# Altium schematic sheet ASR-2DF.SchDoc
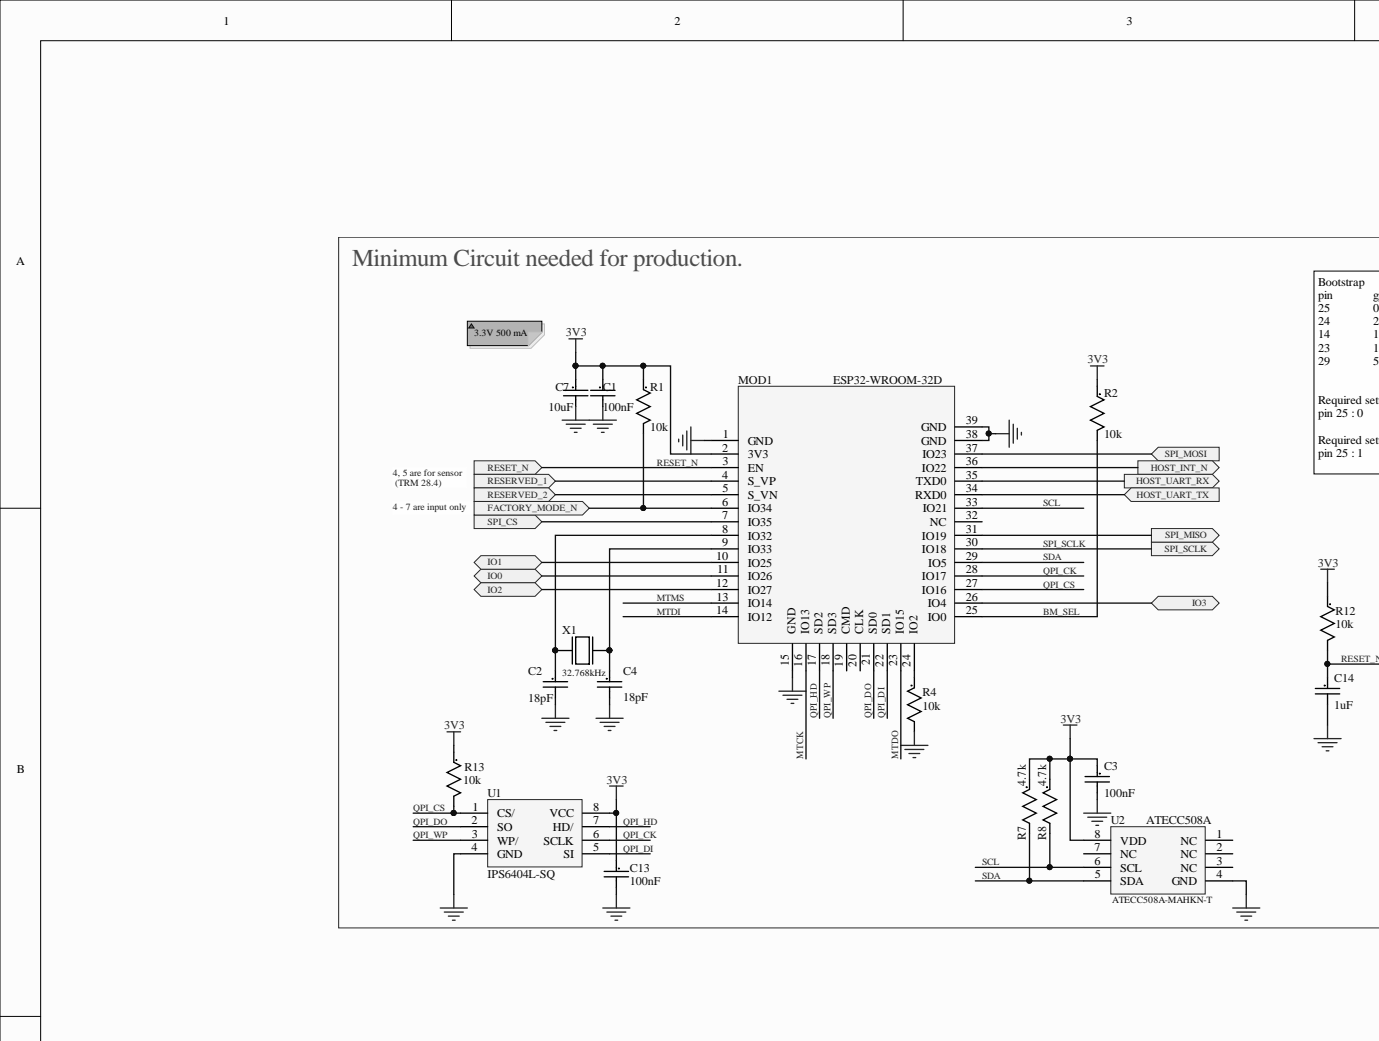
[diagram p0: ASR-2DF.SchDoc, top left]
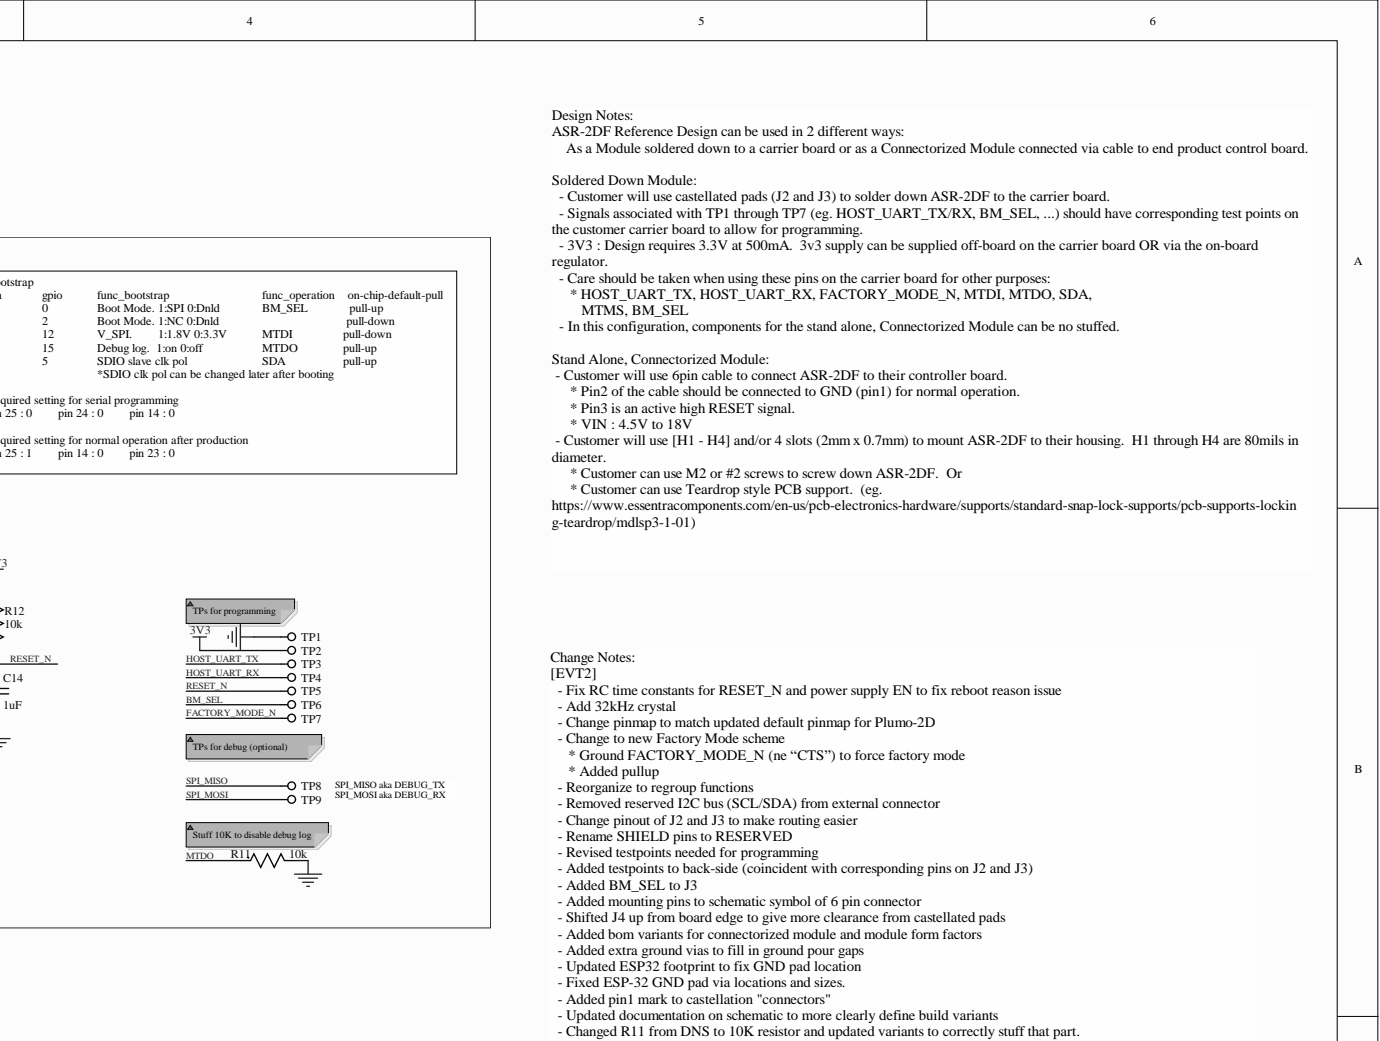
[diagram p1: ASR-2DF.SchDoc, top right]
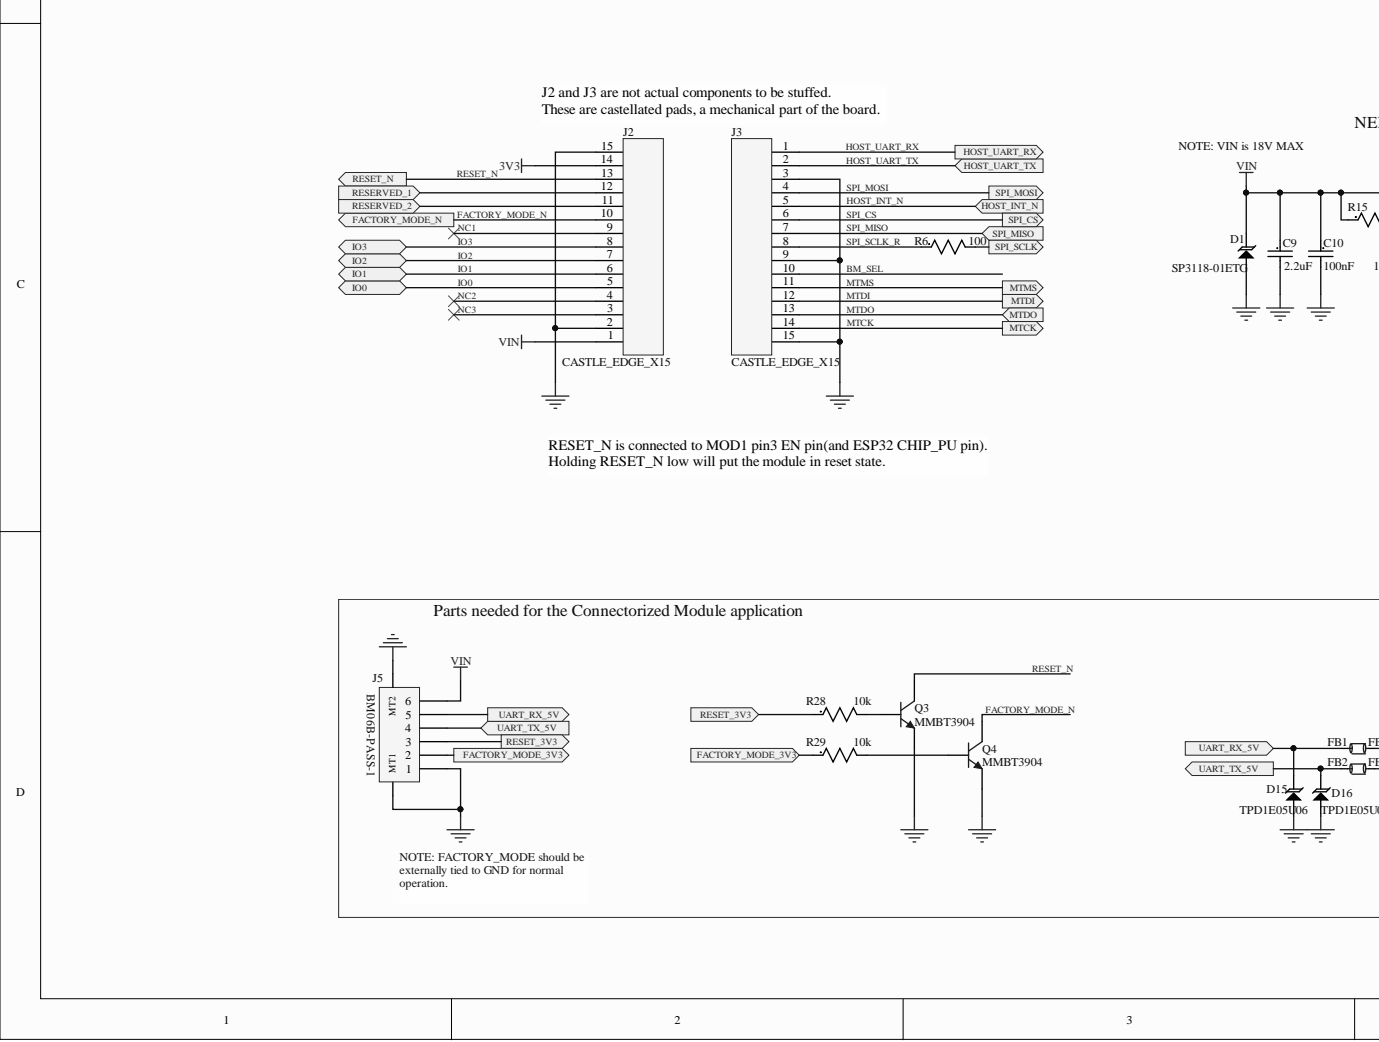
[diagram p2: ASR-2DF.SchDoc, bottom left]
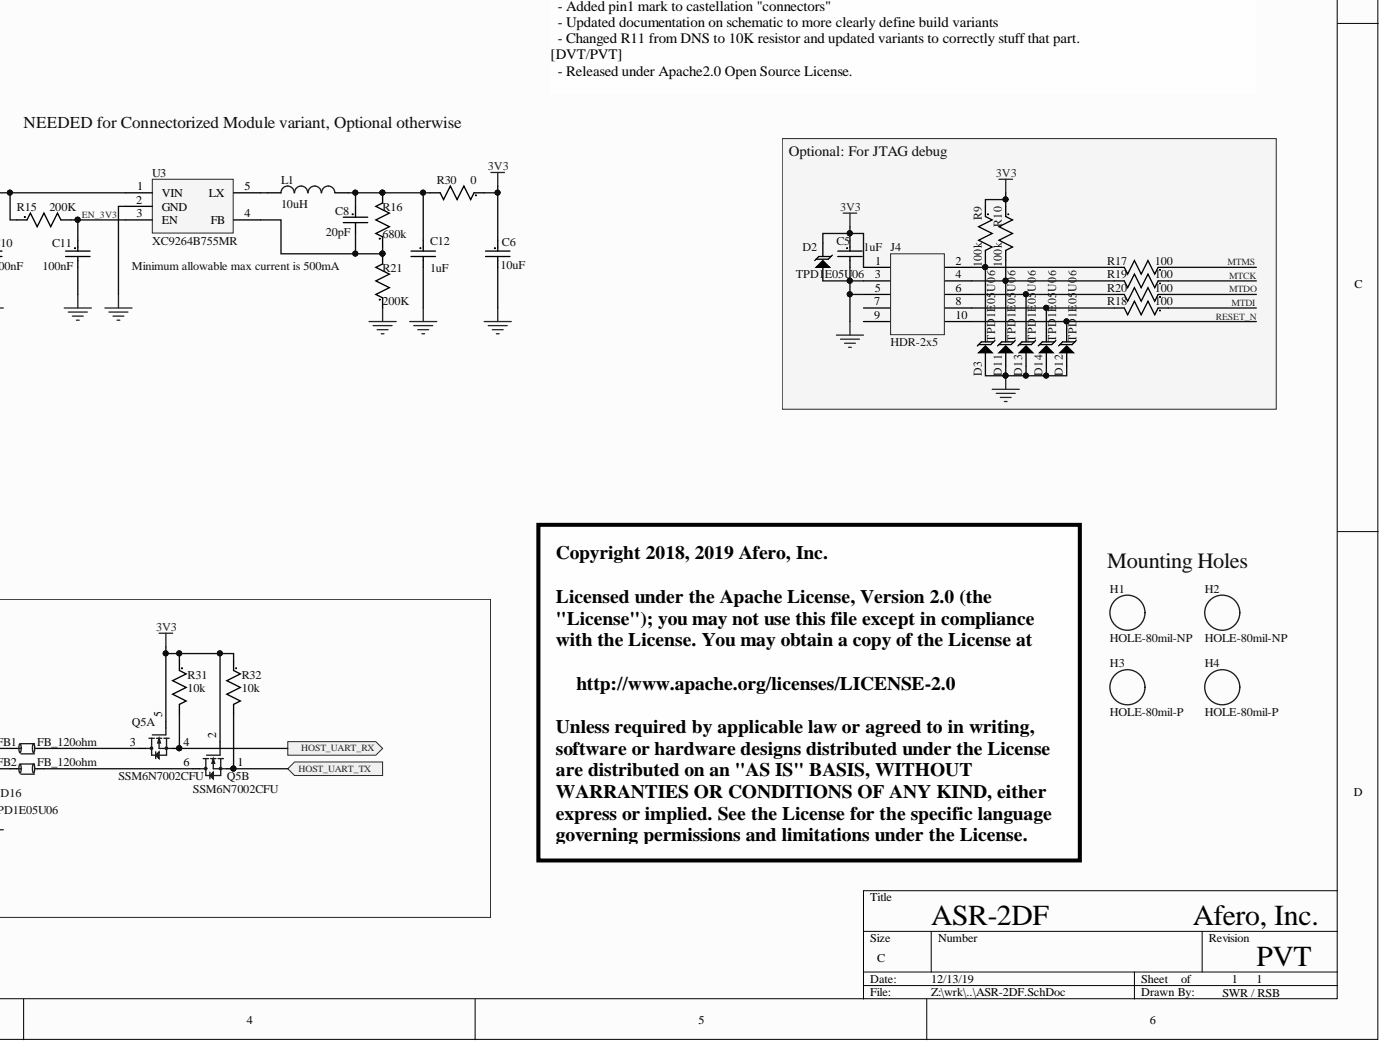
[diagram p3: ASR-2DF.SchDoc, bottom right]

## Parts
C1  100nF  [0402C-GENERIC]
C2  18pF  [0402C-GENERIC]
C3  100nF  [0402C-GENERIC]
C4  18pF  [0402C-GENERIC]
C5  1uF  [0402C-GENERIC]
C6  10uF  [0603C-GENERIC]
C7  10uF  [0603C-GENERIC]
C8  20pF  [0402C-GENERIC]
C9  2.2uF  [0603C-GENERIC]
C10  100nF  [0402C-GENERIC]
C11  100nF  [0402C-GENERIC]
C12  1uF  [0402C-GENERIC]
C13  100nF  [0402C-GENERIC]
C14  1uF  [0402C-GENERIC]
D1  SP3118-01ETG  [SOD882]
D2  TPD1E05U06  [SON70P100X45-2N]
D3  TPD1E05U06  [SON70P100X45-2N]
D11  TPD1E05U06  [SON70P100X45-2N]
D12  TPD1E05U06  [SON70P100X45-2N]
D13  TPD1E05U06  [SON70P100X45-2N]
D14  TPD1E05U06  [SON70P100X45-2N]
D15  TPD1E05U06  [SON70P100X45-2N]
D16  TPD1E05U06  [SON70P100X45-2N]
FB1  FB_120ohm  [BLM15]
FB2  FB_120ohm  [BLM15]
H1  HOLE-80mil-NP  [HOLE-80mil-NP]  (no BOM)
H2  HOLE-80mil-NP  [HOLE-80mil-NP]  (no BOM)
H3  HOLE-80mil-P  [HOLE-80mil-P]  (no BOM)
H4  HOLE-80mil-P  [HOLE-80mil-P]  (no BOM)
J2  CASTLE_EDGE_X15  [CASTL-1X15]  (no BOM)
J3  CASTLE_EDGE_X15  [CASTL-1X15]  (no BOM)
J4  HDR-2x5  [S9015E-05]
J5  BM06B-PASS-1  [BM06B-PASS-1]
L1  10uH  [ASPI-0315FS]
MOD1  ESP32-WROOM-32D  [ESP32-WROOM-32D]
Q3  MMBT3904  [sot23]
Q4  MMBT3904  [sot23]
Q5  SSM6N7002CFU  [SOT363]  (parts A,B)
R1  10k  [0402R-GENERIC]
R2  10k  [0402R-GENERIC]
R4  10k  [0402R-GENERIC]
R6  100  [0402R-GENERIC]
R7  4.7k  [0402R-GENERIC]
R8  4.7k  [0402R-GENERIC]
R9  100k  [0402R-GENERIC]
R10  100k  [0402R-GENERIC]
R11  10k  [0402R-GENERIC]
R12  10k  [0402R-GENERIC]
R13  10k  [0402R-GENERIC]
R15  200K  [0402R-GENERIC]
R16  680k  [0402R-GENERIC]
R17  100  [0402R-GENERIC]
R18  100  [0402R-GENERIC]
R19  100  [0402R-GENERIC]
R20  100  [0402R-GENERIC]
R21  200K  [0402R-GENERIC]
R28  10k  [0402R-GENERIC]
R29  10k  [0402R-GENERIC]
R30  0  [0402R-GENERIC]
R31  10k  [0402R-GENERIC]
R32  10k  [0402R-GENERIC]
TP1  TP1MM  [TP1MM]  (value not drawn)  (no BOM)
TP2  TP1MM  [TP1MM]  (value not drawn)  (no BOM)
TP3  TP1MM  [TP1MM]  (value not drawn)  (no BOM)
TP4  TP1MM  [TP1MM]  (value not drawn)  (no BOM)
TP5  TP1MM  [TP1MM]  (value not drawn)  (no BOM)
TP6  TP1MM  [TP1MM]  (value not drawn)  (no BOM)
TP7  TP1MM  [TP1MM]  (value not drawn)  (no BOM)
TP8  TP1MM  [TP1MM]  (value not drawn)  (no BOM)
TP9  TP1MM  [TP1MM]  (value not drawn)  (no BOM)
U1  IPS6404L-SQ  [IPUS.PSRAM]
U2  ATECC508A  Manufacturer Part Number: ATECC508A-MAHKN-T  [SON50P300X60-8N]
U3  XC9264B755MR  [SOT95P275X130-5N]
X1  32.768kHz  [ABS06]

## Ports
FACTORY_MODE_3V3, FACTORY_MODE_N, HOST_INT_N, HOST_UART_RX, HOST_UART_TX, IO0, IO1, IO2, IO3, MTCK, MTDI, MTDO, MTMS, RESERVED_1, RESERVED_2, RESET_3V3, RESET_N, SPI_CS, SPI_MISO, SPI_MOSI, SPI_SCLK, UART_RX_5V, UART_TX_5V

## Nets
3V3: C1.1 C3.1 C5.1 C6.1 C7.1 C13.1 D2.1 J2.14 J4.1 MOD1.2(3V3) Q5.2 Q5.5 R1.1 R2.1 R7.1 R8.1 R9.1 R10.1 R12.1 R13.1 R30.1 R31.1 R32.1 TP2.1 U1.8(VCC) U2.8(VDD)
GND: C1.2 C2.2 C3.2 C4.2 C5.2 C6.2 C7.2 C9.2 C10.2 C11.2 C12.2 C13.2 C14.2 D1.2 D2.2 D3.2 D11.2 D12.2 D13.2 D14.2 D15.2 D16.2 J2.2 J2.15 J3.3 J3.9 J3.15 J4.3 J4.5 J5.1 J5.7(MT1) J5.8(MT2) MOD1.1(GND) MOD1.15(GND) MOD1.38(GND) MOD1.39(GND) Q3.2 Q4.2 R4.2 R11.2 R21.1 TP1.1 U1.4(GND) U2.4(GND) U3.2(GND)
VIN: C9.1 C10.1 D1.1 J2.1 J5.6 R15.1 U3.1(VIN)
BM_SEL: J3.10 MOD1.25(IO0) R2.2 TP6.1
EN_3V3: C11.1 R15.2 U3.3(EN)
FACTORY_MODE_3V3: J5.2 R29.1 ; port FACTORY_MODE_3V3
FACTORY_MODE_N: J2.10 MOD1.6(IO34) Q4.3 R1.2 TP7.1 ; port FACTORY_MODE_N
HOST_INT_N: J3.5 MOD1.36(IO22) ; port HOST_INT_N
HOST_UART_RX: J3.1 MOD1.35(TXD0) Q5.4 R31.2 TP4.1 ; port HOST_UART_RX
HOST_UART_TX: J3.2 MOD1.34(RXD0) Q5.1 R32.2 TP3.1 ; port HOST_UART_TX
IO0: J2.5 MOD1.11(IO26) ; port IO0
IO1: J2.6 MOD1.10(IO25) ; port IO1
IO2: J2.7 MOD1.12(IO27) ; port IO2
IO3: J2.8 MOD1.26(IO4) ; port IO3
MTCK: J3.14 MOD1.16(IO13) R19.1 ; port MTCK
MTDI: J3.12 MOD1.14(IO12) R18.1 ; port MTDI
MTDO: J3.13 MOD1.23(IO15) R11.1 R20.1 ; port MTDO
MTMS: J3.11 MOD1.13(IO14) R17.1 ; port MTMS
NC1: J2.9
NC2: J2.4
NC3: J2.3
QPI_CK: MOD1.28(IO17) U1.6(SCLK)
QPI_CS: MOD1.27(IO16) R13.2 U1.1(CS/)
QPI_DI: MOD1.22(SD1) U1.5(SI)
QPI_DO: MOD1.21(SD0) U1.2(SO)
QPI_HD: MOD1.17(SD2) U1.7(HD/)
QPI_WP: MOD1.18(SD3) U1.3(WP/)
RESERVED_1: J2.12 MOD1.4(S_VP) ; port RESERVED_1
RESERVED_2: J2.11 MOD1.5(S_VN) ; port RESERVED_2
RESET_3V3: J5.3 R28.1 ; port RESET_3V3
RESET_N: C14.1 D12.1 J2.13 J4.10 MOD1.3(EN) Q3.3 R12.2 TP5.1 ; port RESET_N
SCL: MOD1.33(IO21) R8.2 U2.6(SCL)
SDA: MOD1.29(IO5) R7.2 U2.5(SDA)
SPI_CS: J3.6 MOD1.7(IO35) ; port SPI_CS
SPI_MISO: J3.7 MOD1.31(IO19) TP8.1 ; port SPI_MISO
SPI_MOSI: J3.4 MOD1.37(IO23) TP9.1 ; port SPI_MOSI
SPI_SCLK: MOD1.30(IO18) R6.2 ; port SPI_SCLK
SPI_SCLK_R: J3.8 R6.1
UART_RX_5V: D15.1 FB1.1 J5.5 ; port UART_RX_5V
UART_TX_5V: D16.1 FB2.1 J5.4 ; port UART_TX_5V
NetC2_1: C2.1 MOD1.8(IO32) X1.2
NetC4_1: C4.1 MOD1.9(IO33) X1.1
NetC8_1: C8.1 C12.1 L1.2 R16.2 R30.2
NetC8_2: C8.2 R16.1 R21.2 U3.4(FB)
NetD3_1: D3.1 J4.2 R9.2 R17.2
NetD11_1: D11.1 J4.4 R10.2 R19.2
NetD13_1: D13.1 J4.6 R20.2
NetD14_1: D14.1 J4.8 R18.2
NetFB1_2: FB1.2 Q5.3
NetFB2_2: FB2.2 Q5.6
NetL1_1: L1.1 U3.5(LX)
NetMOD1_24: MOD1.24(IO2) R4.1
NetQ3_1: Q3.1 R28.2
NetQ4_1: Q4.1 R29.2

Not connected: J4 7 9; MOD1 19(CMD) 20(CLK) 32(NC); U2 1(NC) 2(NC) 3(NC) 7(NC)

## Library data of the parts
C1 C3 C10 C11 C13 = 0402C-100n: Capacitor 100nF X5R 50V 10% 0402; Manufacturer: Murata; Manufacturer Part Number: GRM155R61H104KE19D; item_number: E-CAP-00090-00; Capacitance: 100nF; Case/Package: 0402; Case Code (Imperial): 0402; Case Code (Metric): 1005; Component Kind: Standard; Depth: 0.5mm; Dielectric: X5R; Height: 0.5mm; Lead Free: Lead Free; Length: 1mm; Max Operating Temperature: 85°C; Min Operating Temperature: -55°C; Mount: Surface Mount; Packaging: Tape and Reel; Pricing 1: 1=0.1, 10=0.068, 50=0.068, 100=0.03, 1000=0.017, 10000=0.011 (USD); REACH SVHC: No SVHC; Stock 1: 727844; Supplier 1: Mouser; Supplier Part Number 1: 81-GRM155R61H104KE9D; Thickness: 0.022inch; Tolerance: 10%; Voltage: 50V; Voltage Rating: 50V; Voltage Rating (DC): 50V; Width: 0.02inch; Library Name: ASR-2DF.IntLib
C2 C4 = 0402C-18p: Capacitor, 18pF, 50V, 1%, C0G; Manufacturer: Murata; Manufacturer Part Number: GJM1555C1H180FB01D; item_number: E-CAP-00110-00; Capacitance: 18pF; Case/Package: 0402; Case Code (Imperial): 0402; Case Code (Metric): 1005; Component Kind: Standard; Depth: 0.5mm; Dielectric: C0G; Height: 0.5mm; Lead Free: Lead Free; Length: 1mm; Max Operating Temperature: 125°C; Min Operating Temperature: -55°C; Mount: Surface Mount; Package Quantity: 10000; Packaging: Tape and Reel; Radiation Hardening: No; REACH SVHC: No SVHC; Termination: SMD/SMT; Thickness: 0.022inch; Tolerance: 1%; Voltage Rating: 50V; Voltage Rating (DC): 50V; Width: 0.02inch; Library Name: ASR-2DF.IntLib
C5 C12 C14 = 0402C-1u: Capacitor 1uF X5R 6.3V 20% 0402; Manufacturer Part Number: CL05A105KQ5NNNC; Brand: SEM; Capacitance - nF: 1000 nF; item_number: E-CAP-00020-00; Type: Chip Monolithic Ceramic Capacitor; Value: 1u; Voltage Rating DC: 6.3 VDC; Capacitance: 1uF; Case Code - in: 0402; Case Code - mm: 1005; Category: Multilayer Ceramic Capacitors MLCC - SMD/SMT; Component Kind: Standard; Dielectric: X5R; Height: 0.5 mm; Length: 1 mm; Manufacturer: SEM; Maximum Operating Temperature: + 85 C; Minimum Operating Temperature: - 55 C; Minimum Order Quantity: 1; Package / Case: 0402 (1005 metric); Packaging: Cut Tape; Product: General Type MLCCs; Reeling: True; RoHS: RoHS Compliant; RoHS - Mouser: Y; Series: GRM; Standard Pack Qty: 50000; Termination Style: SMD/SMT; Tolerance: 20 %; Width: 0.5 mm; Library Name: ASR-2DF.IntLib
C6 C7 = 0603C-10u: Capacitor 10uF X5R 16V 20% 0603; Manufacturer: Murata; Manufacturer Part Number: GRM188R61C106MA73D; item_number: E-CAP-00100-00; Material: Ceramic; Value: 10u; Capacitance: 10uF; Case/Package: 0603; Case Code (Imperial): 0603; Case Code (Metric): 1608; Component Kind: Standard; Depth: 0.8mm; Dielectric: X5R; Height: 1mm; Lead Free: Lead Free; Length: 1.6mm; Max Operating Temperature: 85°C; Min Operating Temperature: -55°C; Mount: Surface Mount; Package Quantity: 4000; Packaging: Tape and Reel; REACH SVHC: No SVHC; Termination: SMD/SMT; Thickness: 0.037inch; Tolerance: 20%; Voltage Rating: 16V; Voltage Rating (DC): 16V; Weight: 0.000222oz; Width: 0.8mm; Library Name: ASR-2DF.IntLib
C8 = 0402C-20p: Capacitor 20pF C0G 50V 1% 0402; Manufacturer: Murata; Manufacturer Part Number: GJM1555C1H200FB01D; item_number: E-CAP-00107-00; Capacitance: 20pF; Case/Package: 0402; Case Code (Imperial): 0402; Case Code (Metric): 1005; Component Kind: Standard; Depth: 0.5mm; Dielectric: C0G; Height: 0.5mm; Lead Free: Lead Free; Length: 1mm; Max Operating Temperature: 125°C; Min Operating Temperature: -55°C; Mount: Surface Mount; Package Quantity: 10000; Packaging: Tape and Reel; Radiation Hardening: No; REACH SVHC: No SVHC; Termination: SMD/SMT; Thickness: 0.022inch; Tolerance: 1%; Voltage Rating: 50V; Voltage Rating (DC): 50V; Width: 0.02inch; Library Name: ASR-2DF.IntLib
C9 = 0603C-2u2: Capacitor 2.2uF X5R 25V 10% 0603; Manufacturer: Murata; Manufacturer Part Number: GRM188R61E225KA12D; item_number: E-CAP-00108-00; Material: Ceramic; Value: 2u2; Capacitance: 2.2uF; Case/Package: 0603; Case Code (Imperial): 0603; Case Code (Metric): 1608; Component Kind: Standard; Depth: 0.8mm; Dielectric: X5R; Height: 0.9mm; Lead Free: Lead Free; Length: 1.6mm; Max Operating Temperature: 85°C; Min Operating Temperature: -55°C; Mount: Surface Mount; Package Quantity: 4000; Packaging: Tape and Reel; REACH SVHC: No SVHC; Termination: SMD/SMT; Thickness: 0.037inch; Tolerance: 10%; Voltage Rating: 25V; Voltage Rating (DC): 25V; Weight: 0.000222oz; Width: 0.8mm; Library Name: ASR-2DF.IntLib
D1 = SP3118-01ETG: Diode TVS; Manufacturer: Littelfuse; Capacitance: 0.3pF; Clamping Voltage: 38V; Direction: Bidirectional; Element Configuration: Single; item_number: E-DIO-00011-00; Leakage Current: 50nA; Max Power Dissipation: 76W; Number of Bidirectional Channels: 1; Operating Supply Voltage: 18V; Peak Pulse Current: 2A; Power Line Protection: Yes; Component Kind: Standard; Lead Free: Lead Free; Length: 1mm; Manufacturer Part Number: SP3118-01ETG; Max Operating Temperature: 125°C; Min Breakdown Voltage: -; Min Operating Temperature: -40°C; Mount: Surface Mount; Number of Channels: 1; Number of Pins: 2; Package Quantity: 10000; Packaging: Tape and Reel; Peak Pulse Power: 76W; Polarity: Bidirectional; Radiation Hardening: No; REACH SVHC: No SVHC; Reverse Standoff Voltage: 18V; Termination: SMD/SMT; Working Voltage: 18V; Library Name: ASR-2DF.IntLib
D2 D3 D11-D16 = TPD1E05U06: Diode TVS; Manufacturer: Texas Instruments; Manufacturer Part Number: TPD1E05U06DPYR; Breakdown Voltage: 6.5V; Capacitance: 0.5pF; Clamping Voltage: 14V; Direction: Unidirectional; Element Configuration: Single; Interface: LVDS,USB,Ethernet,HDMI,PCIe,SATA; item_number: E-DIO-00008-00; Leakage Current: 10nA; Max Power Dissipation: 40W; Min Breakdown Voltage: 6V; Number of Bidirectional Channels: 1; Operating Supply Voltage: 5.5V; Peak Pulse Current: 2.5A; Polarity: Bidirectional; Power Line Protection: Yes; Component Kind: Standard; ComponentLink1Description: https://datasheet.ciiva.com/12545/tpd1e05u06-224685-12545140.pdf; ComponentLink1URL: https://datasheet.ciiva.com/12545/tpd1e05u06-224685-12545140.pdf; ComponentLink2Description: https://datasheet.ciiva.com/26918/getdatasheetpartid-700473-26918022.pdf; ComponentLink2URL: https://datasheet.ciiva.com/26918/getdatasheetpartid-700473-26918022.pdf; ComponentLink3Description: https://datasheet.ciiva.com/6574/tpd1e05u06-6574667.pdf; ComponentLink3URL: https://datasheet.ciiva.com/6574/tpd1e05u06-6574667.pdf; Lead Free: Lead Free; Length: 1.1mm; Max Operating Temperature: 125°C; Min Operating Temperature: -40°C; Mount: Surface Mount; Number of Channels: 1; Number of Pins: 2; Package Quantity: 10000; Packaging: Tape and Reel; Peak Pulse Power: 40W; Pricing 1: 1=0.5, 10=0.372, 100=0.2107, 500=0.1395, 1000=0.107 (USD); Radiation Hardening: No; REACH SVHC: No SVHC; Reverse Standoff Voltage: 5.5V; Stock 1: 161045; Supplier 1: Digi-Key; Supplier Part Number 1: 296-39341-1-ND; Termination: SMD/SMT; Working Voltage: 5.5V; Library Name: ASR-2DF.IntLib
FB1 FB2 = FB_120ohm: FERRITE BEAD 120OHM 0402 1LN; Manufacturer: Murata; Manufacturer Part Number: BLM15AG121SN1D; CLASS: DISCRETE; item_number: E-IND-00038-00; Component Kind: Standard; Library Name: ASR-2DF.IntLib
H1 H2 = HOLE-80mil-NP: 0.08" Unplated Hole with 0.1" keepout, #2 screw; Library Name: ASR-2DF.IntLib
H3 H4 = HOLE-80mil-P: 0.08" Plated Hole, #2 screw; Library Name: ASR-2DF.IntLib
J2 J3 = CASTLE_EDGE_X15: 0.07" castellated board edge connector 15 positions; Library Name: ASR-2DF.IntLib
J4 = HDR-2x5: .050 Male Header 2x5; Manufacturer Part Number: GRPB052VWVN-RC; item_number: M-CON-00016-00; Component Kind: Standard; Library Name: ASR-2DF.IntLib
J5 = BM06B-PASS-1: JST PA-Family Vertical Header 2mm; Manufacturer Part Number: BM06B-PASS-1-TFT; item_number: M-CON-00088; Component Kind: Standard; Manufacturer: JST; Library Name: ASR-2DF.IntLib
L1 = ASPI-0315FS-100M: Inductor 10uH 1.1A 0.22ohm 20% 3x3; Manufacturer: Abracon; item_number: E-IND-00043-00; Component Kind: Standard; Manufacturer Part Number: ASPI-0315FS-100M; Value: 10uH; Library Name: ASR-2DF.IntLib
MOD1 = ESP32-WROOM-32D: Module WIFI BLE ESP32-D0WD SPI Flash; Manufacturer: Espressif; Item_number: E-ICS-00097-00; Component Kind: Standard; Manufacturer Part Number: ESP32-WROOM-32D; Size: 25.5mm x 18mm; Library Name: ASR-2DF.IntLib
Q3 Q4 = MMBT3904: TR NPN BJT 200mA 40V; Manufacturer: On Semiconductor; Manufacturer Part Number: MMBT3904LT1G; item_number: E-TRS-00002-00; Component Kind: Standard; ComponentLink1Description: Manufacturer Link; ComponentLink1URL: http://www.fairchildsemi.com/; ComponentLink2Description: Datasheet; ComponentLink2URL: http://www.fairchildsemi.com/ds/2N%2F2N3904.pdf; Package/Case: SOT23; Library Name: ASR-2DF.IntLib
Q5 = SSM6N7002CFU: TR 2xNFET 170mA 60V; Manufacturer: Toshiba; Manufacturer Part Number: SSM6N7002CFU.LF; item_number: E-TRS-00009-00; Case/Package: SOT-363; Component Kind: Standard; Library Name: ASR-2DF.IntLib
R1 R2 R4 R11-R13 R28 R29 R31 R32 = 0402R-10k: Resistor 10K 50V 5% 0402; Manufacturer: Vishay Dale; Manufacturer Part Number: CRCW040210K0JNED; item_number: E-RES-00001-00; Max Power Dissipation: 63mW; Temperature Coefficient: 200ppm/°C; Case/Package: 0402; Case Code (Imperial): 0402; Case Code (Metric): 1005; Component Kind: Standard; ComponentLink1Description: https://datasheet.ciiva.com/2461/dcrcwe3-2461974.pdf; ComponentLink1URL: https://datasheet.ciiva.com/2461/dcrcwe3-2461974.pdf; ComponentLink2Description: https://datasheet.ciiva.com/2461/dcrcwe3-2461975.pdf; ComponentLink2URL: https://datasheet.ciiva.com/2461/dcrcwe3-2461975.pdf; ComponentLink3Description: https://datasheet.ciiva.com/2461/dcrcwe3-2461976.pdf; ComponentLink3URL: https://datasheet.ciiva.com/2461/dcrcwe3-2461976.pdf; ComponentLink4Description: https://datasheet.ciiva.com/7743/dcrcwe3-7743416.pdf; ComponentLink4URL: https://datasheet.ciiva.com/7743/dcrcwe3-7743416.pdf; ComponentLink5Description: https://datasheet.ciiva.com/16771/70201119-16771096.pdf; ComponentLink5URL: https://datasheet.ciiva.com/16771/70201119-16771096.pdf; ComponentLink6Description: https://datasheet.ciiva.com/7743/dcrcwe3-7743417.pdf; ComponentLink6URL: https://datasheet.ciiva.com/7743/dcrcwe3-7743417.pdf; ComponentLink7Description: https://datasheet.ciiva.com/16761/70201119-16761947.pdf; ComponentLink7URL: https://datasheet.ciiva.com/16761/70201119-16761947.pdf; ComponentLink8Description: https://datasheet.ciiva.com/3255/dcrcwe3-3255964.pdf; ComponentLink8URL: https://datasheet.ciiva.com/3255/dcrcwe3-3255964.pdf; ComponentLink9Description: https://datasheet.ciiva.com/3255/dcrcwe3-3255965.pdf; ComponentLink9URL: https://datasheet.ciiva.com/3255/dcrcwe3-3255965.pdf; Composition: Thick Film; Contact Plating: Tin; Depth: 0.5mm; Features: Automotive AEC-Q200; Height: 0.016inch; Length: 1mm; Max Operating Temperature: 155°C; Military Standard: Not; Min Operating Temperature: -55°C; Mount: Surface Mount; Number of Terminations: 2; Package Quantity: 1; Packaging: Tape and Reel; Power Rating: 63mW; Pricing 1: 1=0.1, 10=0.031, 100=0.0125, 1000=0.0056, 2500=0.0049, 5000=0.004 (USD); Radiation Hardening: No; REACH SVHC: Unknown; Resistance: 10k; Stock 1: 0; Supplier 1: Digi-Key; Supplier Part Number 1: 541-10KJCT-ND; Termination: SMD/SMT; Tolerance: 5%; Voltage Rating: 50V; Weight: 2.3E-05oz; Width: 0.5mm; Library Name: ASR-2DF.IntLib
R6 R17-R20 = 0402R-100R: Resistor 100R 50V 5% 0402; Manufacturer: Panasonic; Manufacturer Part Number: ERJ-2GEJ101X; item_number: E-RES-00008-00; Max Power Dissipation: 100mW; Temperature Coefficient: 100ppm/°C; Case/Package: 0402; Case Code (Imperial): 0402; Case Code (Metric): 1005; Component Kind: Standard; Composition: Thick Film; Contact Plating: Tin; Depth: 0.5mm; Features: Automotive AEC-Q200; Height: 0.35mm; Lead Free: Lead Free; Length: 1mm; Max Operating Temperature: 155°C; Military Standard: Not; Min Operating Temperature: -55°C; Mount: Surface Mount; Number of Terminations: 2; Package Quantity: 10; Packaging: Tape and Reel; Power Rating: 100mW; Pricing 1: 1=0.1, 10=0.044, 100=0.0178, 1000=0.008, 2500=0.007, 5000=0.0058 (USD); Radiation Hardening: No; REACH SVHC: Unknown; Resistance: 100; Termination: SMD/SMT; Tolerance: 5%; Voltage Rating: 50V; Voltage Rating (DC): 50V; Weight: 2.3E-05oz; Width: 0.02inch; Working Voltage: 50V; Library Name: ASR-2DF.IntLib
R7 R8 = 0402R-4k7: Resistor 4.7K 50V 5% 0402; Manufacturer: Panasonic; Manufacturer Part Number: ERJ-2GEJ472X; item_number: E-RES-00039-00; Max Power Dissipation: 100mW; Temperature Coefficient: 200ppm/°C; Case/Package: 0402; Case Code (Imperial): 0402; Case Code (Metric): 1005; Component Kind: Standard; ComponentLink1Description: https://datasheet.ciiva.com/11821/0900766b80f806dd-11821328.pdf; ComponentLink1URL: https://datasheet.ciiva.com/11821/0900766b80f806dd-11821328.pdf; ComponentLink2Description: https://datasheet.ciiva.com/16758/70260739-16758341.pdf; ComponentLink2URL: https://datasheet.ciiva.com/16758/70260739-16758341.pdf; ComponentLink3Description: https://datasheet.ciiva.com/16770/70260739-16770309.pdf; ComponentLink3URL: https://datasheet.ciiva.com/16770/70260739-16770309.pdf; ComponentLink4Description: https://datasheet.ciiva.com/28514/1678796-28514168.pdf; ComponentLink4URL: https://datasheet.ciiva.com/28514/1678796-28514168.pdf; ComponentLink5Description: https://datasheet.ciiva.com/17213/aoa0000ce1-17213178.pdf; ComponentLink5URL: https://datasheet.ciiva.com/17213/aoa0000ce1-17213178.pdf; ComponentLink6Description: https://datasheet.ciiva.com/16523/aoa0000ce1-16523499.pdf; ComponentLink6URL: https://datasheet.ciiva.com/16523/aoa0000ce1-16523499.pdf; ComponentLink7Description: https://datasheet.ciiva.com/46377/1678796-46377565.pdf; ComponentLink7URL: https://datasheet.ciiva.com/46377/1678796-46377565.pdf; Composition: Thick Film; Contact Plating: Tin; Depth: 0.5mm; Features: Automotive AEC-Q200; Height: 0.35mm; Lead Free: Lead Free; Length: 1mm; Max Operating Temperature: 155°C; Military Standard: Not; Min Operating Temperature: -55°C; Mount: Surface Mount; Number of Terminations: 2; Package Quantity: 1; Packaging: Cut Tape; Power Rating: 100mW; Pricing 1: 1=0.1, 10=0.038, 100=0.0154, 1000=0.0069, 2500=0.006, 5000=0.005 (USD); Radiation Hardening: No; REACH SVHC: No SVHC; Resistance: 4.7k; Stock 1: 10404054; Supplier 1: Digi-Key; Supplier Part Number 1: P4.7KJCT-ND; Termination: SMD/SMT; Tolerance: 5%; Voltage Rating: 50V; Voltage Rating (DC): 50V; Width: 0.5mm; Library Name: ASR-2DF.IntLib
R9 R10 = 0402R-100k: Resistor 100K 50V 5% 0402; Manufacturer: Panasonic; Manufacturer Part Number: ERJ-2GEJ104X; item_number: E-RES-00012-00; Max Power Dissipation: 100mW; Temperature Coefficient: 200ppm/°C; Case/Package: 0402; Case Code (Imperial): 0402; Case Code (Metric): 1005; Component Kind: Standard; ComponentLink1Description: https://datasheet.ciiva.com/11821/0900766b80f806dd-11821174.pdf; ComponentLink1URL: https://datasheet.ciiva.com/11821/0900766b80f806dd-11821174.pdf; ComponentLink2Description: https://datasheet.ciiva.com/22758/1500035-22758198.pdf; ComponentLink2URL: https://datasheet.ciiva.com/22758/1500035-22758198.pdf; ComponentLink3Description: https://datasheet.ciiva.com/34303/1986799-34303543.pdf; ComponentLink3URL: https://datasheet.ciiva.com/34303/1986799-34303543.pdf; ComponentLink4Description: https://datasheet.ciiva.com/24023/aoa0000ce1-37422-24023480.pdf; ComponentLink4URL: https://datasheet.ciiva.com/24023/aoa0000ce1-37422-24023480.pdf; ComponentLink5Description: https://datasheet.ciiva.com/16777/70260739-16777321.pdf; ComponentLink5URL: https://datasheet.ciiva.com/16777/70260739-16777321.pdf; ComponentLink6Description: https://datasheet.ciiva.com/16759/70260739-16759692.pdf; ComponentLink6URL: https://datasheet.ciiva.com/16759/70260739-16759692.pdf; ComponentLink7Description: https://datasheet.ciiva.com/48531/aoa0000ce1-48531084.pdf; ComponentLink7URL: https://datasheet.ciiva.com/48531/aoa0000ce1-48531084.pdf; ComponentLink8Description: https://datasheet.ciiva.com/9186/aoa0000ce1-9186685.pdf; ComponentLink8URL: https://datasheet.ciiva.com/9186/aoa0000ce1-9186685.pdf; ComponentLink9Description: https://datasheet.ciiva.com/30204/4aoa0000ce1-30204557.pdf; ComponentLink9URL: https://datasheet.ciiva.com/30204/4aoa0000ce1-30204557.pdf; ComponentLink10Description: https://datasheet.ciiva.com/46389/1500035-46389645.pdf; ComponentLink10URL: https://datasheet.ciiva.com/46389/1500035-46389645.pdf; Composition: Thick Film; Contact Plating: Tin; Depth: 0.5mm; Features: Automotive AEC-Q200; Height: 0.35mm; Lead Free: Lead Free; Length: 1mm; Max Operating Temperature: 155°C; Military Standard: Not; Min Operating Temperature: -55°C; Mount: Surface Mount; Number of Terminations: 2; Package Quantity: 1; Packaging: Tape and Reel; Power Rating: 100mW; Pricing 1: 1=0.1, 10=0.038, 100=0.0154, 1000=0.0069, 2500=0.006, 5000=0.005 (USD); Radiation Hardening: No; REACH SVHC: No SVHC; Resistance: 100k; Stock 1: 10583442; Supplier 1: Digi-Key; Supplier Part Number 1: P100KJCT-ND; Termination: SMD/SMT; Tolerance: 5%; Voltage Rating: 50V; Voltage Rating (DC): 50V; Width: 0.02inch; Library Name: ASR-2DF.IntLib
R15 R21 = 0402R-200k: Resistor 200K 50V 1% 0402; Manufacturer: Panasonic; Manufacturer Part Number: ERJ-2RKF2003X; item_number: E-RES-00054-00; Max Power Dissipation: 100mW; Temperature Coefficient: 200ppm/°C; Case/Package: 0402; Case Code (Imperial): 0402; Case Code (Metric): 1005; Component Kind: Standard; Composition: Thick Film; Contact Plating: Tin; Depth: 0.5mm; Features: Automotive AEC-Q200; Height: 0.35mm; Lead Free: Lead Free; Length: 1mm; Max Operating Temperature: 155°C; Military Standard: Not; Min Operating Temperature: -55°C; Mount: Surface Mount; Number of Terminations: 2; Package Quantity: 1; Packaging: Tape and Reel; Power Rating: 100mW; Radiation Hardening: No; REACH SVHC: No SVHC; Resistance: 200K; Termination: SMD/SMT; Tolerance: 1%; Voltage Rating: 50V; Voltage Rating (DC): 50V; Width: 0.02inch; Library Name: ASR-2DF.IntLib
R16 = 0402R-680k: Resistor 680K 50V 5% 0402; Manufacturer: Panasonic; Manufacturer Part Number: ERJ-2GEJ684X; item_number: E-RES-00093-00; Max Power Dissipation: 100mW; Temperature Coefficient: 200ppm/°C; Case/Package: 0402; Case Code (Imperial): 0402; Case Code (Metric): 1005; Component Kind: Standard; Composition: Thick Film; Contact Plating: Tin; Depth: 0.5mm; Features: Automotive AEC-Q200; Height: 0.35mm; Lead Free: Lead Free; Length: 1mm; Max Operating Temperature: 155°C; Military Standard: Not; Min Operating Temperature: -55°C; Mount: Surface Mount; Number of Terminations: 2; Package Quantity: 1; Packaging: Tape and Reel; Power Rating: 100mW; Radiation Hardening: No; REACH SVHC: No SVHC; Resistance: 680k; Stock 1: 10583442; Supplier 1: Digi-Key; Supplier Part Number 1: P100KJCT-ND; Termination: SMD/SMT; Tolerance: 5%; Voltage Rating: 50V; Voltage Rating (DC): 50V; Width: 0.02inch; Library Name: ASR-2DF.IntLib
R30 = 0402R-0R0: Resistor 0R 50V 1% 0402; Manufacturer: Yageo; Manufacturer Part Number: RC0402FR-070RL; item_number: E-RES-00006-00; Power Rating: 62.5mW; Resistance: 0mR; Temperature Coefficient: 200ppm/°C; Case/Package: 0402; Case Code (Imperial): 0402; Case Code (Metric): 1005; Component Kind: Standard; ComponentLink1Description: https://datasheet.ciiva.com/8896/pyu-rc0402-51-rohs-l-6-8896149.pdf; ComponentLink1URL: https://datasheet.ciiva.com/8896/pyu-rc0402-51-rohs-l-6-8896149.pdf; ComponentLink2Description: https://datasheet.ciiva.com/16790/pyu-rc0402-51-rohs-l-6-r-16790444.pdf; ComponentLink2URL: https://datasheet.ciiva.com/16790/pyu-rc0402-51-rohs-l-6-r-16790444.pdf; ComponentLink3Description: https://datasheet.ciiva.com/28419/pyu-rc0402-51-rohs-l-6-28419661.pdf; ComponentLink3URL: https://datasheet.ciiva.com/28419/pyu-rc0402-51-rohs-l-6-28419661.pdf; ComponentLink4Description: https://datasheet.ciiva.com/16869/pyu-rc0402-51-rohs-l-6-16869518.pdf; ComponentLink4URL: https://datasheet.ciiva.com/16869/pyu-rc0402-51-rohs-l-6-16869518.pdf; Composition: Thick Film; Contact Plating: Tin,Matte; Height: 0.35mm; Lead Free: Lead Free; Length: 1mm; Max Operating Temperature: 155°C; Min Operating Temperature: -55°C; Packaging: Tape and Reel; Pricing 1: 1=0.1, 10=0.01, 50=0.01, 100=0.003, 1000=0.002, 10000=0.002 (USD); Radiation Hardening: No; Stock 1: 240535; Supplier 1: Mouser; Supplier Part Number 1: 603-RC0402FR-070RL; Termination: SMD/SMT; Tolerance: 1%; Value: 0; Voltage Rating: 50V; Width: 0.5mm; Library Name: ASR-2DF.IntLib
TP1-TP9 = TP1MM: 1mm Test Point; item_number: item_name; Library Name: ASR-2DF.IntLib
U1 = IPS6404L-SQ: Memory SPI/QPI PSRAM 8MB SOIC8 150mil; Manufacturer: IPUS; Manufacturer Part Number: IPS6404L-SQ-SPN; item_number: E-ICS-00099-00; Component Kind: Standard; Package / Case: 8 SOIC 150mil; Library Name: ASR-2DF.IntLib
U2 = ATECC508A: Afero Custom CryptoAuthentication Device; Manufacturer: Microchip / Atmel; item_number: E-ICS-00072-00; Component Kind: Standard; Max Operating Temperature: 85°C; Min Operating Temperature: -40°C; Mount: Surface Mount; Packaging: Tape and Reel; Pricing 1: 1=0.35, 25=0.32, 100=0.29 (USD); Stock 1: 4638; Supplier 1: Digi-Key; Supplier Part Number 1: ATECC508A-MAHDA-TCT-ND; Library Name: ASR-2DF.IntLib
U3 = XC9264B755MR: Voltage Regulator 0.5A 500KHz Buck; Manufacturer: Torex; item_number: E-ICS-00096-00; Component Kind: Standard; Manufacturer Part Number: XC9264B755MR; Package / Case: SOT25; Library Name: ASR-2DF.IntLib
X1 = ABS06-32.768KHZ-T: Crystal, 32.768kHz ±20ppm; Manufacturer: Abracon; ESR (Equivalent Series Resistance): 90kR; Insulation Resistance: 500MR; item_number: E-XTL-00012-00; Load Capacitance: 12.5pF; Case/Package: SMD/SMT; Component Kind: Standard; ComponentLink1Description: http://www.farnell.com/datasheets/1796520.pdf; ComponentLink1URL: http://www.farnell.com/datasheets/1796520.pdf; ComponentLink2Description: https://abracon.com/Support/PackageDrawing/Resonators/ABS06.PDF; ComponentLink2URL: https://abracon.com/Support/PackageDrawing/Resonators/ABS06.PDF; ComponentLink3Description: http://www.farnell.com/datasheets/1912788.pdf; ComponentLink3URL: http://www.farnell.com/datasheets/1912788.pdf; ComponentLink4Description: http://www.abracon.com/Resonators/ABS06.pdf; ComponentLink4URL: http://www.abracon.com/Resonators/ABS06.pdf; Depth: 1.2mm; Frequency: 32.768kHz; Frequency Stability: 20ppm; Frequency Tolerance: 20ppm; Height: 0.024inch; Lead Free: Lead Free; Length: 2mm; Manufacturer Part Number: ABS06-32.768KHZ-T; Max Operating Temperature: 85°C; Min Operating Temperature: -40°C; Mount: Surface Mount; Number of Pins: 2; Package Quantity: 3000; Packaging: Tape and Reel; Pricing 1: 1=1.34, 10=1.277, 50=1.2474, 100=1.0692, 500=1.0395, 1000=0.8762 (USD); Radiation Hardening: No; REACH SVHC: No SVHC; Series Resistance: 90kR; Stock 1: 65982; Supplier 1: Digi-Key; Supplier Part Number 1: 535-10104-1-ND; Termination: SMD/SMT; Tolerance: 20ppm; Width: 1.2mm; Library Name: ASR-2DF.IntLib
